# Altium schematic sheet ICS.SchDoc(ICS)
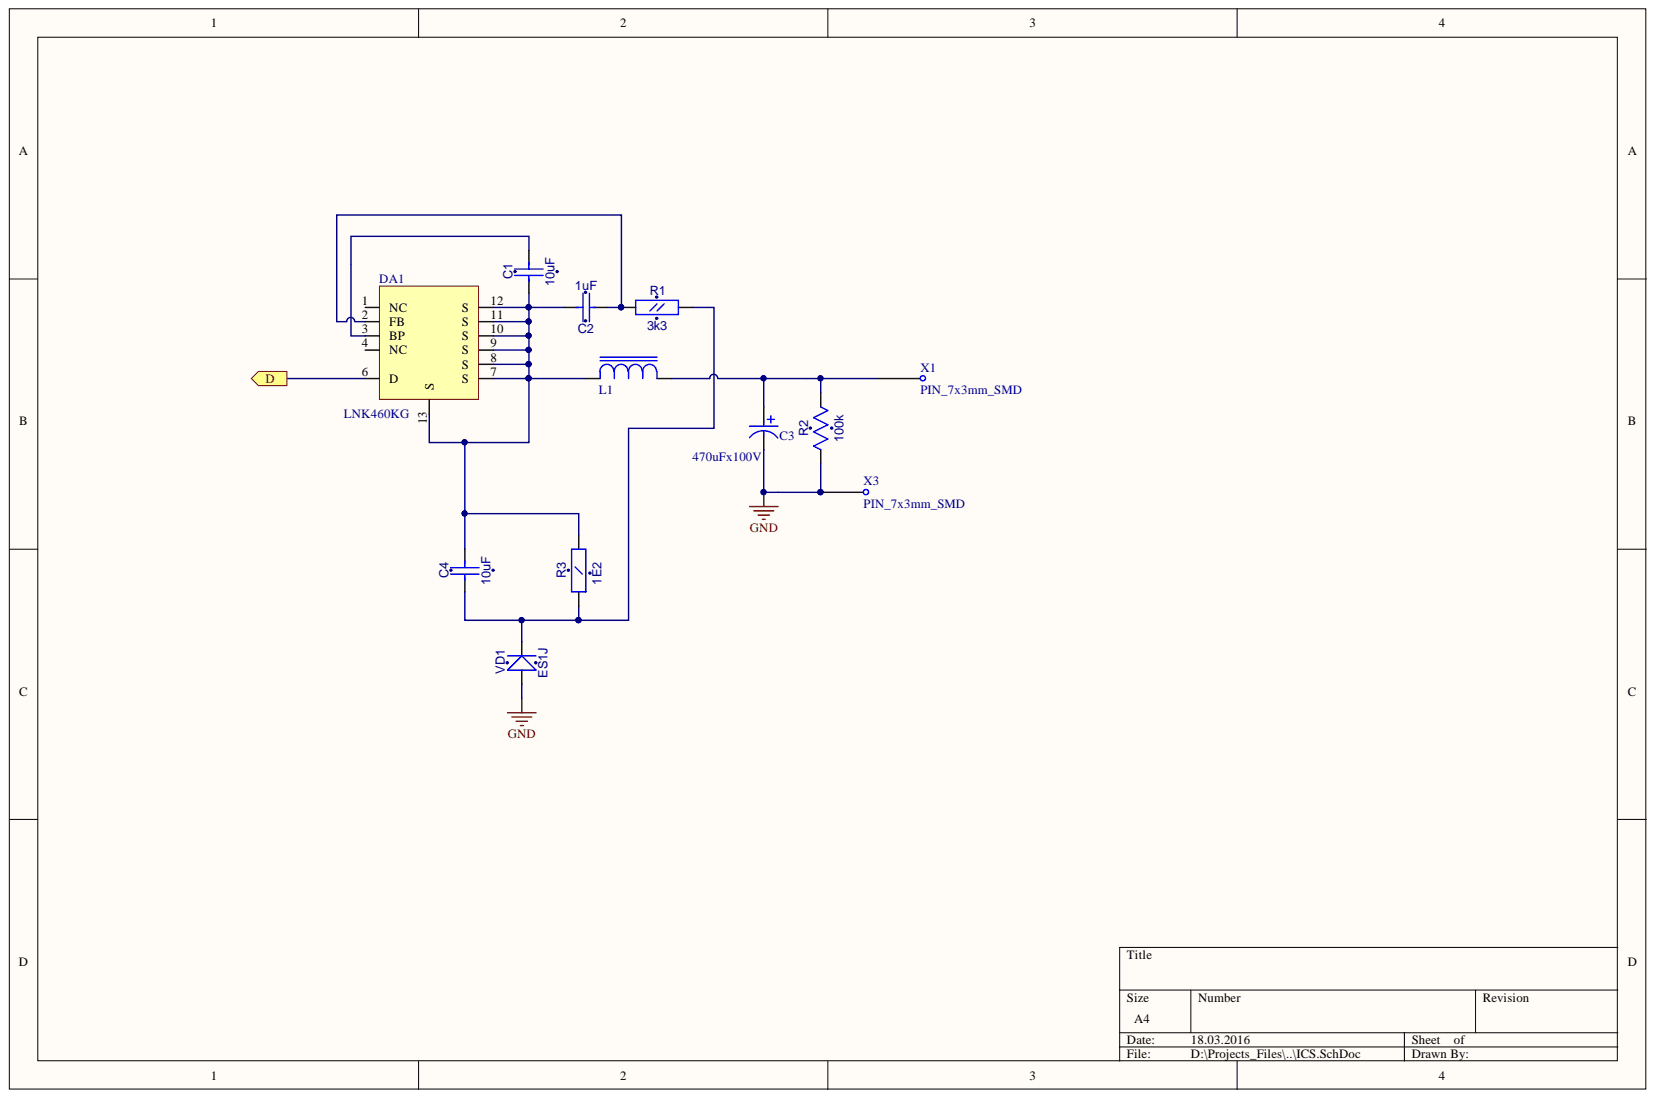
[diagram p0: ICS.SchDoc]

## Parts
C1  10uF  [CC1206]
C2  1uF  [CC0805]
C3  470uFx100V  [CAP_7.5_SMD_2]
C4  10uF  [CC1206]
DA1  LNK460KG  [eSOP-12]
L1  CDRH129HF-331MC  [IND_CDRH129]  (value not drawn)
R1  3k3  [RC0805]
R2  100k  [RC0805]
R3  1E2  [RC1206]
VD1  ES1J  [SMA]
X1  PIN_7x3mm_SMD  [PIN_7x3mm_SMD]
X3  PIN_7x3mm_SMD  [PIN_7x3mm_SMD]

## Ports
D

## Nets
GND: C3.1 R2.1 VD1.1 X3.1
D: DA1.6(D) ; port D
NetC1_1: C1.1 C2.2 C4.2 DA1.7(S) DA1.8(S) DA1.9(S) DA1.10(S) DA1.11(S) DA1.12(S) DA1.13(S) L1.1 R3.2
NetC1_2: C1.2 DA1.3(BP)
NetC2_1: C2.1 DA1.2(FB) R1.1
NetC3_2: C3.2 L1.2 R2.2 X1.1
NetC4_1: C4.1 R1.2 R3.1 VD1.2

Not connected: DA1 1(NC) 4(NC)

## Library data of the parts
C1 C4 = CC1206: Component Kind: Standard; Description: CC1206; Value: 10uF; Library Name: gprs_modem_2.SchLib
C2 = CC0805: Component Kind: Standard; Description: CC0805; Value: 1uF; Library Name: gprs_modem_2.SchLib
C3 = CAP_16x25: Component Kind: Standard; Value: 470uFx100V; Library Name: gprs_modem_2.SchLib
L1 = CDRH129: Component Kind: Standard; Value: CDRH129HF-331MC; Library Name: gprs_modem_2.SchLib
R1 R2 = RC0805: Component Kind: Standard; Description: RC0805
  R1: Value: 3k3; Library Name: gprs_modem_2.SchLib
  R2: Value: 100k; Library Name: shlagbaum.SchLib
R3 = RC1206: Component Kind: Standard; Description: RC1206; Value: 1E2; Library Name: gprs_modem_2.SchLib
VD1 = SS14: Schottky Rectifier; Component Kind: Standard; Value: ES1J; Library Name: gprs_modem.SchLib
DA1 = LNK460KG: Component Kind: Standard; Value: LNK460KG; Library Name: gprs_modem.SchLib
X1 X3 = PIN_7x3mm_SMD: Component Kind: Standard; Library Name: gprs_modem.SchLib
